# Altium schematic sheet Power.SchDoc(Power)
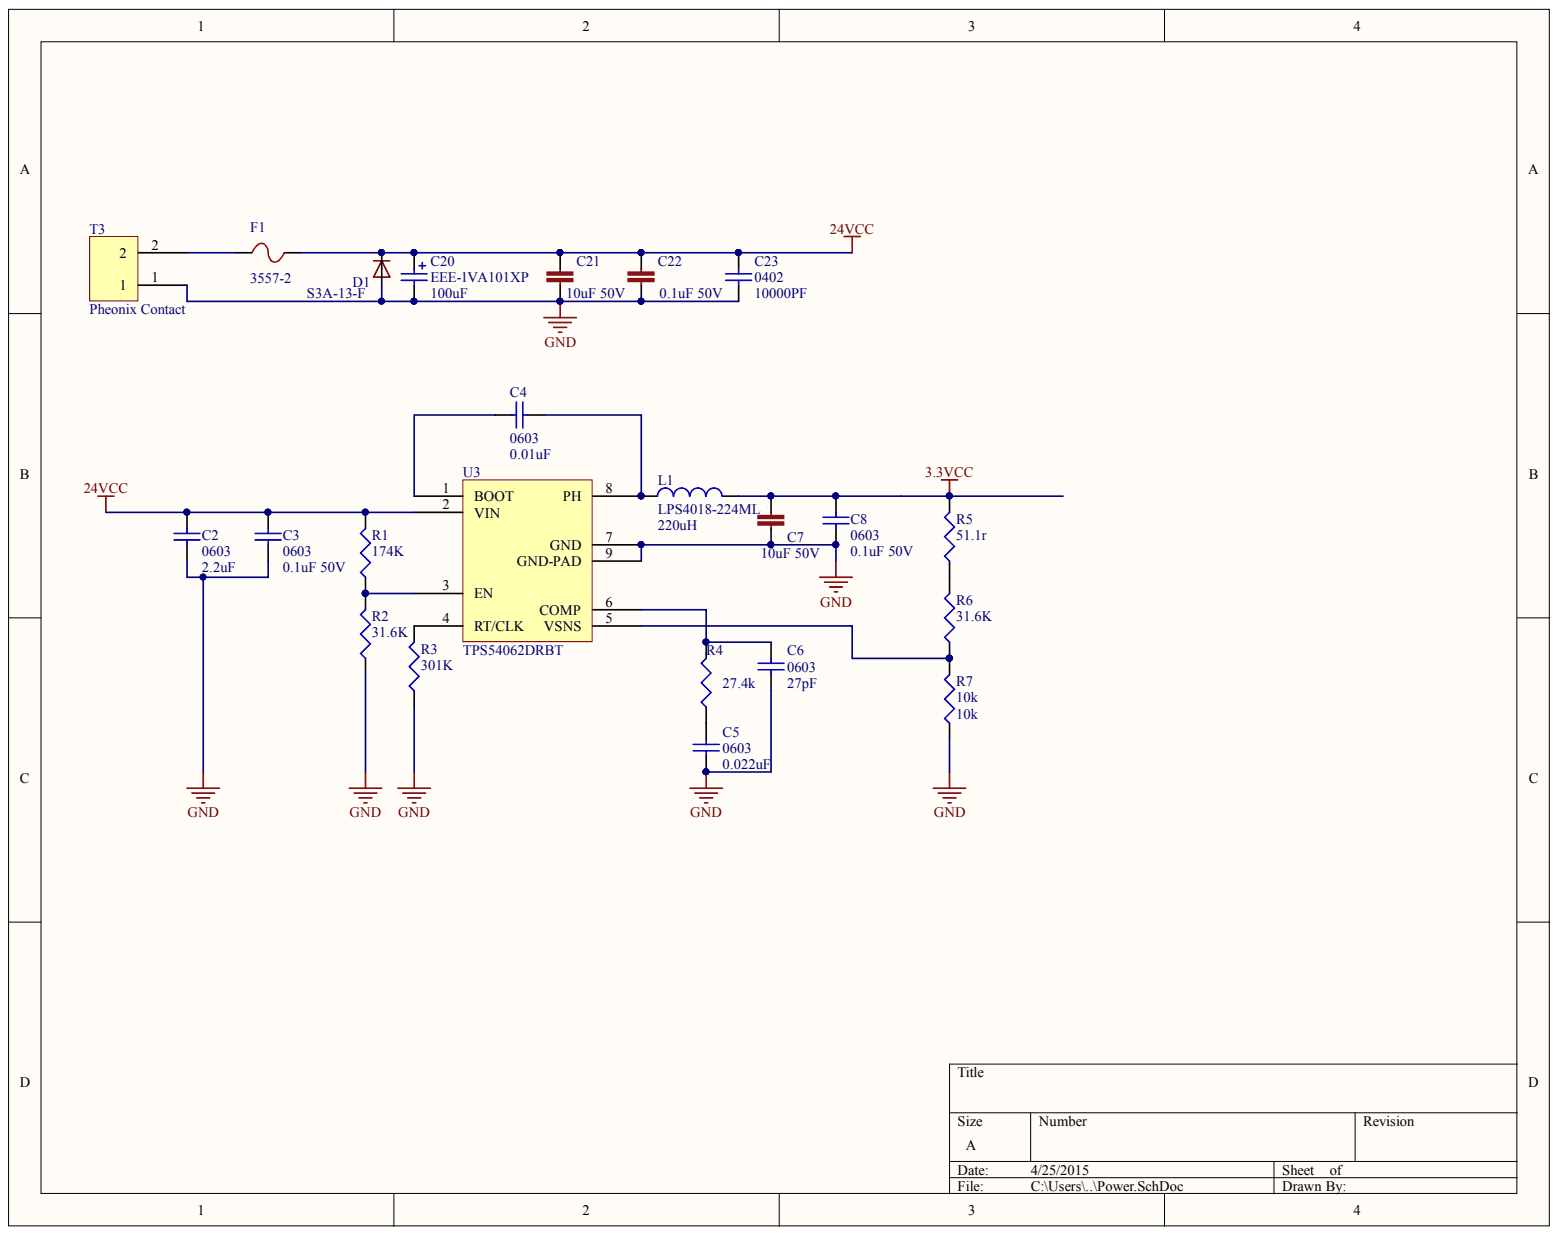
[diagram p0: Power.SchDoc]

## Parts
C2  0603  value 2.2uF  [C0805]
C3  0603  value 0.1uF 50V  [C0603]
C4  0603  value 0.01uF  [C0603]
C5  0603  value 0.022uF  [C0603]
C6  0603  value 27pF  [C0603]
C7  10uF 50V  [C1206]
C8  0603  value 0.1uF 50V  [C0603]
C20  EEE-1VA101XP  value 100uF  [EEE-1VA101XP]
C21  10uF 50V  [C1206]
C22  0.1uF 50V  [C0603]
C23  0402  value 10000PF  [C0402]
D1  S3A-13-F  [DO-214AB]
F1  3557-2  [3557]
L1  LPS4018-224ML  value 220uH  [LPS4018-224ML]
R1  174K  [R0603]
R2  31.6K  [R0603]
R3  301K  [R0603]
R4  27.4k  [R0603]
R5  51.1r  [R0603]
R6  31.6K  [R0603]
R7  10k  [R0603]
T3  Pheonix Contact  [CON-PHEONIX-508-Alt]
U3  TPS54062DRBT  [QFN65P300X300X100_HS-17N]

## Nets
3.3VCC: C7.1 C8.2 L1.2 R5.2
24VCC: C2.2 C3.2 C20.1 C21.1 C22.1 C23.2 D1.C F1.2 R1.2 U3.2(VIN)
GND: C2.1 C3.1 C5.1 C6.1 C7.2 C8.1 C20.2 C21.2 C22.2 C23.1 D1.A R2.1 R3.1 R7.1 T3.1 U3.7(GND) U3.9(GND-PAD)
NetC4_1: C4.1 L1.1 U3.8(PH)
NetC4_2: C4.2 U3.1(BOOT)
NetC5_2: C5.2 R4.1
NetC6_2: C6.2 R4.2 U3.6(COMP)
NetF1_1: F1.1 T3.2
NetR1_1: R1.1 R2.2 U3.3(EN)
NetR3_2: R3.2 U3.4(RT/CLK)
NetR5_1: R5.1 R6.2
NetR6_1: R6.1 R7.2 U3.5(VSNS)

## Library data of the parts
C2 = CL21A225KB9LNNC: CAP CER 2.2UF 50V 10% X5R 0805; MFR: Samsung; Voltage: 50VDC; Component Kind: Standard; Component Type: Chip Capacitor; Datasheet: http://www.samsungsem.com/kr/front/downloadcms.do?path=/kr/support/product-search/mlcc/__icsFiles/afieldfile/2014/11/05&fileName=S_CL21A225KB9LNNC.pdf; Digikey #: 1276-2409-1-ND; Height: 1mm; LatestRevisionDate: April 2015; MFR Part #: CL21A225KB9LNNC; Mounting Type: SMT 0805; PackageReference: 0805; Part Description: CAP CER 2.2UF 50V 10% X5R 0805; Published: 10 - Dec - 2014; Signal Integrity: Cap Semi; Simulation: CAP; Tolerance: 10%; Library Name: MongoosMini.SchLib
C3 C8 = CL10B104KB8SFNC: CAP CER 0.1UF 50V 10% X7R 0603; MFR: Samsung; Voltage: 50VDC; Component Kind: Standard; Component Type: Chip Capacitor; Datasheet: http://www.samsungsem.com/kr/front/downloadcms.do?path=/kr/support/product-search/mlcc/__icsFiles/afieldfile/2014/11/05&fileName=S_CL10B104KB8SFNC.pdf; Digikey #: 1276-1936-1-ND; Height: 0.9mm; LatestRevisionDate: April 2015; MFR Part #: CL10B104KB8SFNC; Mounting Type: SMT 0603; PackageReference: 0603; Part Description: CAP CER 0.1UF 50V 10% X7R 0603; Published: 10 - Dec - 2014; Signal Integrity: Cap Semi; Simulation: CAP; Tolerance: 10%; Library Name: MongoosMini.SchLib
  C3: Value: 0.1uF 50V
C4 = CL10B103KB8NCNC: CAP CER 10000PF 50V 10% X7R 0603; MFR: Samsung; Voltage: 50VDC; Component Kind: Standard; Component Type: Chip Capacitor; Datasheet: http://www.samsungsem.com/kr/front/downloadcms.do?path=/kr/support/product-search/mlcc/__icsFiles/afieldfile/2014/11/05&fileName=S_CL10B103KB8NCNC.pdf; Digikey #: 1276-1921-1-ND; Height: 0.9mm; LatestRevisionDate: April 2015; MFR Part #: CL10B103KB8NCNC; Mounting Type: SMT 0603; PackageReference: 0603; Part Description: CAP CER 10000PF 50V 10% X7R 0603; Published: 10 - Dec - 2014; Signal Integrity: Cap Semi; Simulation: CAP; Tolerance: 10%; Library Name: MongoosMini.SchLib
C5 = CL10B223JB8NNNC: CAP CER 0.022UF 50V 5% X7R 0603; MFR: Samsung; Tolerance: 10%; Voltage: 50VDC; Component Kind: Standard; Component Type: Chip Capacitor; Datasheet: http://www.samsungsem.com/kr/front/downloadcms.do?path=/kr/support/product-search/mlcc/__icsFiles/afieldfile/2014/11/05&fileName=S_CL10B223JB8NNNC.pdf; Digikey #: 1276-1996-1-ND; Height: 0.9mm; LatestRevisionDate: April 2015; MFR Part #: CL10B223JB8NNNC; Mounting Type: SMT 0603; PackageReference: 0603; Part Description: CAP CER 0.022UF 50V 5% X7R 0603; Published: 10 - Dec - 2014; Signal Integrity: Cap Semi; Simulation: CAP; Library Name: MongoosMini.SchLib
C6 = CL10C270JB8NNNC: CAP CER 27PF 50V 5% NP0 0603; MFR: Samsung; Voltage: 50VDC; Component Kind: Standard; Component Type: Chip Capacitor; Datasheet: http://www.samsungsem.com/kr/front/downloadcms.do?path=/kr/support/product-search/mlcc/__icsFiles/afieldfile/2014/11/05&fileName=S_CL10C270JB8NNNC.pdf; Digikey #: 1276-1921-1-ND; Height: 0.9mm; LatestRevisionDate: April 2015; MFR Part #: CL10C270JB8NNNC; Mounting Type: SMT 0603; PackageReference: 0603; Part Description: CAP CER 27PF 50V 5% NP0 0603; Published: 10 - Dec - 2014; Signal Integrity: Cap Semi; Simulation: CAP; Tolerance: 5%; Library Name: MongoosMini.SchLib
C7 C21 = CL31A106KBHNNNE: CAP CER 10UF 50V 10% X5R 1206; Manufacturer: Samsung; LastRevisionNote: ImportComponents; Component Kind: Standard; LastRevisionDate: 25-mar-2015; Library Name: Tigershark3D.SchLib; ManufacturerPartNumber: CL31A106KBHNNNE; Published: 25-mar-2015; Signal Integrity: Model Name; Simulation: Model Name; VALUE: 10uF 50V; Publisher: UltiMachine
C20 = EEE-1VA101XP: CAP ALUM 100UF 35V 20% SMD; Manufacture: Panasonic; Component Kind: Standard; Datasheet: http://industrial.panasonic.com/www-cgi/jvcr13pz.cgi?E+PZ+3+ABA0002+EEE1VA101XP+7+WW; Digikey Part #: PCE3951CT-ND; Height: 7.70mm; Library Name: Tigershark3D.SchLib; Manufacture Part #: EEE-1VA101XP; Mount Type: SMT Panasonic VS D Series; Price: $0.63; Signal Integrity: Cap Pol; Simulation: CAP; Status: Active
C22 = CL10B104KB8SFNC: CAP CER 0.1UF 50V 10% X7R 0603; LastRevisionNote: ImportComponents; Component Kind: Standard; LastRevisionDate: 23-Apr-2014; Library Name: Tigershark3D.SchLib; ManufacturerPartNumber: CL10B104KB8SFNC; Published: 23-Apr-2014; Signal Integrity: Model Name; Simulation: Model Name; VALUE: 0.1uF 50V; Publisher: UltiMachine
C23 = CL05B103JB5NNNC: CAP CER 10000PF 50V 5% X7R 0402; Manufacturer: Samsung; Voltage: 16v; Component Kind: Standard; Component Type: Chip Capacitor; Datasheet: https://www.samsungsem.com/kr/front/downloadcms.do?path=/kr/support/product-search/mlcc/__icsFiles/afieldfile/2014/11/05&fileName=S_CL05B103JB5NNNC.pdf; Height: 0.25 ± 0.15mm; LatestRevisionDate: 10 - Dec - 2014; LatestRevisionNote: Ultimachine Footprint Added; Library Name: Tigershark3D.SchLib; Manufacture Part #: CL05B103JB5NNNC; Mounting Type: SMT 0402; PackageReference: 0402; Part Description: CAP CER 10000PF 50V 5% X7R 0402; Published: 10 - Dec - 2014; Signal Integrity: Cap Semi; Simulation: CAP; Tolerance: 5%; Publisher: Ultimachine
D1 = S3A-13-F: DIODE DO-214AB; LastRevisionNote: ImportComponents; Component Kind: Standard; LastRevisionDate: 23-Apr-2014; Library Name: 3Delight.SCHLIB; Published: 23-Apr-2014; Value: S3A-13-F; Publisher: UltiMachine
F1 = 3557-2: FUSE PTH ATO; LastRevisionNote: ImportComponents; Component Kind: Standard; LastRevisionDate: 23-Apr-2014; Library Name: 3Delight.SCHLIB; ManufacturerPartNumber: 3557-2; Published: 23-Apr-2014; Publisher: UltiMachine
L1 = LPS4018-224ML: Inductor; MFR: Coilcraft; Value: 220uH; Component Kind: Standard; Height: 1.7mm; LatestRevisionDate: April 2015; MFR#: LPS4018-224ML; PackageDescription: Custom Wound SMD Inductor; PackageReference: Coilcraft Custom; Published: 8-Jun-2000; Signal Integrity: Inductor; Simulation: INDUCTOR; Library Name: MongoosMini.SchLib; Publisher: Altium Limited
R1 = RC1608F1743CS: RES SMD 174K OHM 1% 1/10W 0603; MFR: Samsung; Component Kind: Standard; Digikey #: 1276-4857-1-ND; MFR #: RC1608F1743CS; PackageReference: 0603; PDF: http://www.samsungsem.com/global/support/library/product-catalog/__icsFiles/afieldfile/2015/01/12/CHIP_RESISTOR_150112_1.pdf; Signal Integrity: Res; Simulation: RESISTOR; Value: 174K; Library Name: MongoosMini.SchLib
R2 R6 = RC1608F3162CS: RES SMD 31.6K OHM 1% 1/10W 0603; MFR: Samsung; Component Kind: Standard; Digikey #: 1276-4788-1-ND; MFR #: RC1608F3162CS; PackageReference: 0603; PDF: http://www.samsungsem.com/global/support/library/product-catalog/__icsFiles/afieldfile/2015/01/12/CHIP_RESISTOR_150112_1.pdf; Signal Integrity: Res; Simulation: RESISTOR; Value: 31.6K; Library Name: MongoosMini.SchLib
R3 = RC1608F3013CS: RES SMD 301K OHM 1% 1/10W 0603; MFR: Samsung; Component Kind: Standard; Digikey #: 1276-4881-1-ND; MFR #: RC1608F3013CS; PackageReference: 0603; PDF: http://www.samsungsem.com/global/support/library/product-catalog/__icsFiles/afieldfile/2015/01/12/CHIP_RESISTOR_150112_1.pdf; Signal Integrity: Res; Simulation: RESISTOR; Value: 301K; Library Name: MongoosMini.SchLib
R4 = RC1608F2742CS: RES SMD 27.4K OHM 1% 1/10W 0603; MFR: Samsung; Component Kind: Standard; Digikey #: 1276-4782-1-ND; MFR #: RC1608F2742CS; PackageReference: 0603; PDF: http://www.samsungsem.com/global/support/library/product-catalog/__icsFiles/afieldfile/2015/01/12/CHIP_RESISTOR_150112_1.pdf; Signal Integrity: Res; Simulation: RESISTOR; Value: 27.4k; Library Name: MongoosMini.SchLib
R5 = RC1608F51R1CS: RES SMD 51.1 OHM 1% 1/10W 0603; MFR: Samsung; Component Kind: Standard; Digikey #: 1276-4543-1-ND; MFR #: RC1608F51R1CS; PackageReference: 0603; PDF: http://www.samsungsem.com/global/support/library/product-catalog/__icsFiles/afieldfile/2015/01/12/CHIP_RESISTOR_150112_1.pdf; Signal Integrity: Res; Simulation: RESISTOR; Value: 51.1r; Library Name: MongoosMini.SchLib
R7 = RC1608J103CS: RES SMD 10K OHM 5% 1/10W 0603; MFR: Samsung; Component Kind: Standard; Digikey #: 1276-5086-1-ND; MFR #: RC1608J103CS; PackageReference: 0603; PDF: http://www.samsungsem.com/global/support/library/product-catalog/__icsFiles/afieldfile/2015/01/12/CHIP_RESISTOR_150112_1.pdf; Signal Integrity: Res; Simulation: RESISTOR; Value: 10k; Library Name: MongoosMini.SchLib
T3 = Pheonix Contact 2X1: Component Kind: Standard; Library Name: Ribbon Breakout Schem Symbols.SchLib
U3 = TPS54062DRBT: IC REG BUCK SYNC ADJ 50MA DRBT; MFR: Texas Instruments; Component Kind: Standard; MFR#: TPS54062DRBT; Type: DCDC Switching Step Down Regulator IC; Library Name: MongoosMini.SchLib
